# Altium schematic sheet drvoutput3.SchDoc(drvoutput3)
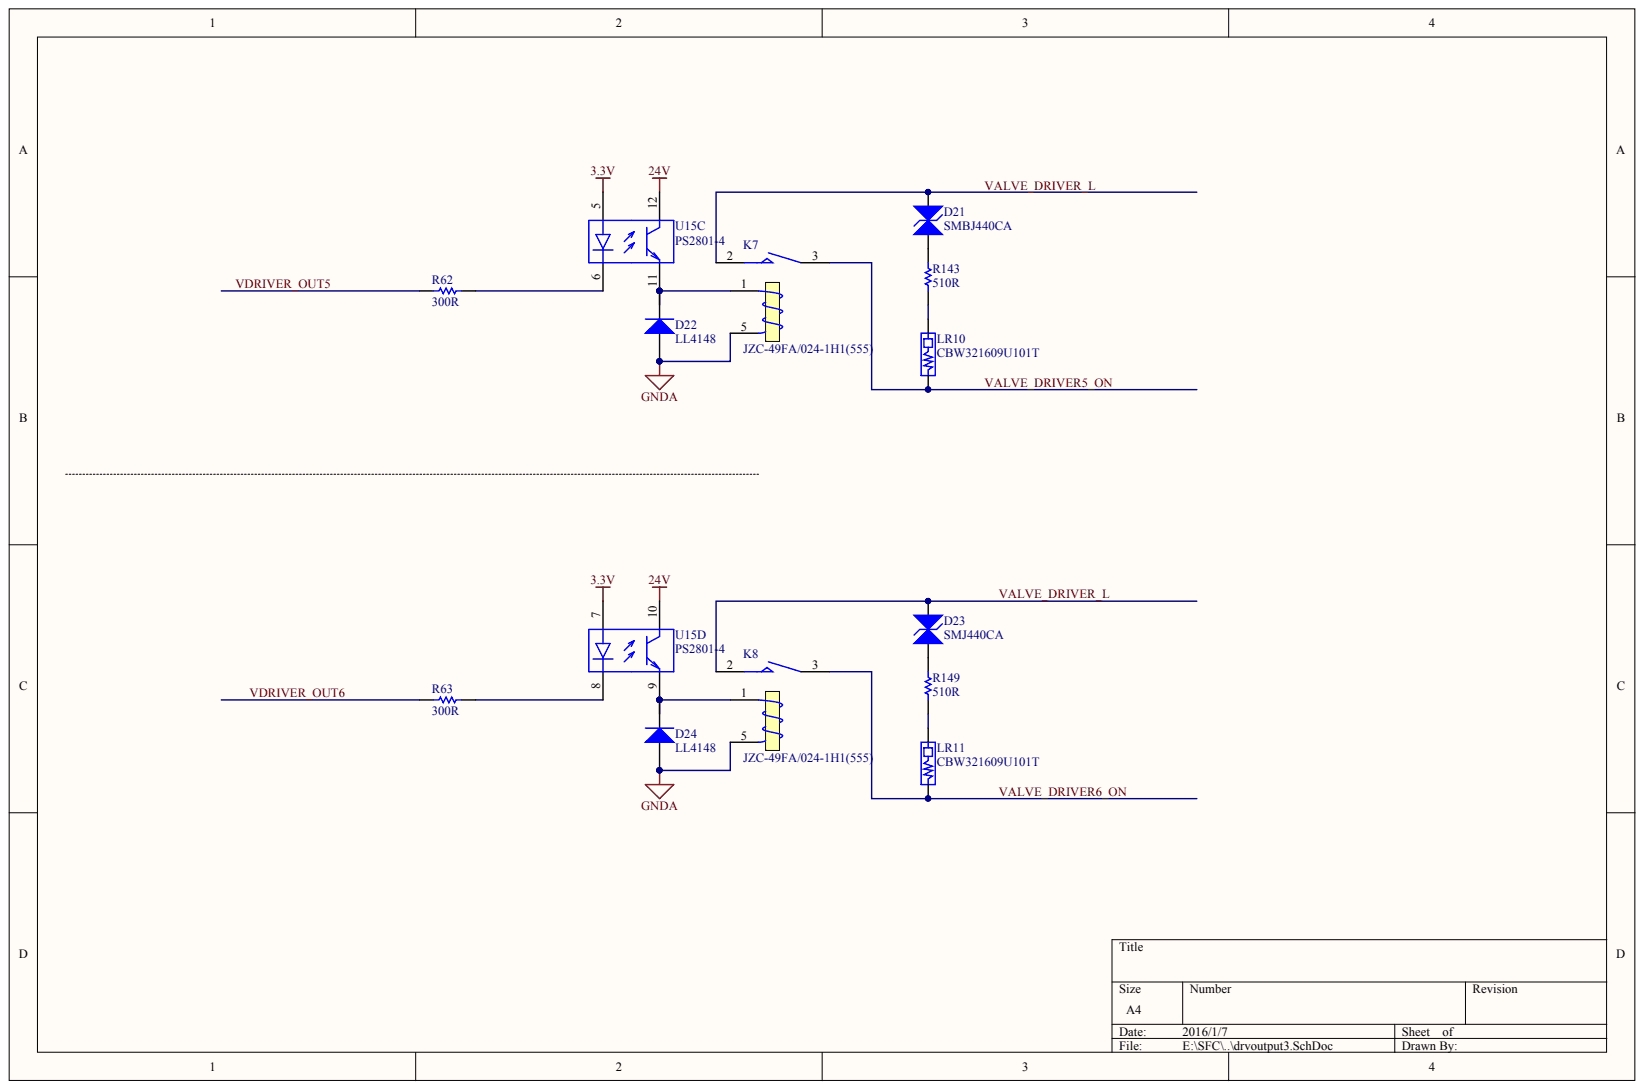
[diagram p0: drvoutput3.SchDoc]

## Parts
D21  SMBJ440CA
D22  LL4148
D23  SMJ440CA
D24  LL4148
K7  JZC-49FA/024-1H1(555)
K8  JZC-49FA/024-1H1(555)
LR10  CBW321609U101T
LR11  CBW321609U101T
R62  300R
R63  300R
R143  510R
R149  510R
U15  PS2801-4  (parts C,D)

## Nets
3.3V: U15.5 U15.7
24V: U15.10 U15.12
GNDA: D22.1 D24.1 K7.5 K8.5
VALVE_DRIVER5_ON: K7.3 LR10.1
VALVE_DRIVER6_ON: K8.3 LR11.1
VALVE_DRIVER_L: D21.2 D23.2 K7.2 K8.2
VDRIVER_OUT5: R62.1
VDRIVER_OUT6: R63.1
NetD21_1: D21.1 R143.2
NetD22_2: D22.2 K7.1 U15.11
NetD23_1: D23.1 R149.2
NetD24_2: D24.2 K8.1 U15.9
NetLR10_2: LR10.2 R143.1
NetLR11_2: LR11.2 R149.1
NetR62_2: R62.2 U15.6
NetR63_2: R63.2 U15.8

## Library data of the parts
R62 R63 R143 R149 = (no symbol name): Value: 10K; Published: 8-Jun-2000; LatestRevisionDate: 17-Jul-2002; LatestRevisionNote: Re-released for DXP Platform.; PackageReference: AXIAL-0.3; Publisher: Altium Limited
D21-D24 K7 K8 LR10 LR11 U15 = (no symbol name)
  D21 D23: Published: 8-Jun-2000; LatestRevisionDate: 17-Jul-2002; LatestRevisionNote: Re-released for DXP Platform.; PackageReference: DIODE-0.7
  D22 D24: Published: 8-Jun-2000; LatestRevisionDate: 17-Jul-2002; LatestRevisionNote: Re-released for DXP Platform.; DatasheetVersion: 1998; PackageVersion: Aug-1999; PackageReference: DO-41; Code_JEDEC: DO-204-AL; ComponentLink1Description: Manufacturer Link; ComponentLink1URL: http://www.fairchildsemi.com/; ComponentLink2Description: Datasheet; ComponentLink2URL: http://www.fairchildsemi.com/ds/1N%2F1N4001.pdf
  K7 K8: Published: 8-Jun-2000; LatestRevisionDate: 17-Jul-2002; LatestRevisionNote: Re-released for DXP Platform.; PackageReference: MODULE5B
  U15: Published: 28-Feb-2003; LatestRevisionDate: 13-Apr-2006; LatestRevisionNote: IPC-7351 Footprint Added.; DatasheetVersion: Jan-2002, Rev. 7; PackageVersion: rev7, Jan-2002; PackageReference: 751-07; PackageDescription: 8-Pin Plastic Small Outline Integrated Circuit 1.27 mm Pitch; Code_JEDEC: MS-012-AA; Code_IPC: SOIC127P600-8; ComponentLink1Description: Manufacturer Link; ComponentLink1URL: http://www.onsemi.com/; ComponentLink2Description: Datasheet; ComponentLink2URL: http://www.onsemi.com/pub/Collateral/LM358-D.PDF
  D21-D24 K7 K8 U15: Publisher: Altium Limited
